# Altium schematic sheet beovox-poe.SchDoc(beovox-poe) (continued)

## Library data of the parts
C1 C3 = GRM32ER72A105KA01L: 1210 1 uF 100 V ±10% Tolerance X7R SMT Multilayer Ceramic Capacitor; Manufacturer: Murata; Case Code (Imperial): 1210; Case Code (Metric): 3225; Case/Package: 1210; Category: Ceramic Capacitors; Component Kind: Standard; ComponentLink1Description: https://datasheet.ciiva.com/11789/0900766b80ef921d-11789096.pdf?src-supplier=RSComponents; ComponentLink1URL: https://datasheet.ciiva.com/11789/0900766b80ef921d-11789096.pdf?src-supplier=RSComponents; ComponentLink2Description: https://datasheet.ciiva.com/3777/2750grm32er72a105ka0123-3777235.pdf?src-supplier=Arrow+Electronics; ComponentLink2URL: https://datasheet.ciiva.com/3777/2750grm32er72a105ka0123-3777235.pdf?src-supplier=Arrow+Electronics; ComponentLink3Description: https://datasheet.ciiva.com/29202/451519-da-01-de-vs-kondens-grm32-er72a-105ka01l-29202131.pdf?src-supplier=Conrad; ComponentLink3URL: https://datasheet.ciiva.com/29202/451519-da-01-de-vs-kondens-grm32-er72a-105ka01l-29202131.pdf?src-supplier=Conrad; ComponentLink4Description: https://datasheet.ciiva.com/7937/608985-7937781.pdf?src-supplier=Element14; ComponentLink4URL: https://datasheet.ciiva.com/7937/608985-7937781.pdf?src-supplier=Element14; ComponentLink5Description: https://datasheet.ciiva.com/22703/608985-22703400.pdf?src-supplier=Element14; ComponentLink5URL: https://datasheet.ciiva.com/22703/608985-22703400.pdf?src-supplier=Element14; ComponentLink6Description: https://datasheet.ciiva.com/141/partnumbering-e-01-141963.pdf?src-supplier=Digi-Key; ComponentLink6URL: https://datasheet.ciiva.com/141/partnumbering-e-01-141963.pdf?src-supplier=Digi-Key; ComponentLink7Description: https://datasheet.ciiva.com/141/partnumbering-e-01-141962.pdf?src-supplier=Digi-Key; ComponentLink7URL: https://datasheet.ciiva.com/141/partnumbering-e-01-141962.pdf?src-supplier=Digi-Key; ComponentLink8Description: https://datasheet.ciiva.com/141/partnumbering-e-01-141964.pdf?src-supplier=Digi-Key; ComponentLink8URL: https://datasheet.ciiva.com/141/partnumbering-e-01-141964.pdf?src-supplier=Digi-Key; ComponentLink9Description: https://datasheet.ciiva.com/5415/product-5415622.pdf?src-supplier=Mouser; ComponentLink9URL: https://datasheet.ciiva.com/5415/product-5415622.pdf?src-supplier=Mouser; ComponentLink10Description: https://datasheet.ciiva.com/16376/c02e-16376506.pdf?src-supplier=Future+Electronics; ComponentLink10URL: https://datasheet.ciiva.com/16376/c02e-16376506.pdf?src-supplier=Future+Electronics; Depth: 2.5mm; Dielectric: X7R; Height: 2.5mm; Lead Free: Lead Free; Length: 3.2mm; Manufacturer Part Number: GRM32ER72A105KA01L; Material: Ceramic; Max Operating Temperature: 125°C; Min Operating Temperature: -55°C; Mount: Surface Mount; Number of Pins: 2; Package Quantity: 1; Packaging: Tape and Reel; Pricing 1: 1000=0.33337, 2000=0.32553, 4000=0.31768, 6000=0.30984, 8000=0.30199 (USD); Reach SVHC Compliant: Yes; RoHS: Yes; RoHS Compliant: Yes; Series: GRM; Stock 1: 22500; Supplier 1: Avnet; Supplier Part Number 1: GRM32ER72A105KA01L; Termination: SMD/SMT; Thickness: 0.106inch; Tolerance: 10%; Voltage: 100V; Voltage Rating: 100V; Voltage Rating (DC): 100V; Width: 0.098inch; Library Name: josh-lib.SchLib
C2 C4 = CC0603KRX7R0BB104: 0603 0.1 uF 100 V ±10% Tolerance X7R Multilayer Ceramic Capacitor; Manufacturer: Yageo; Capacitance: 100nF; Voltage Rating: 100V; Case Code (Imperial): 0603; Case Code (Metric): 1608; Case/Package: 0603; Category: Ceramic Capacitors; Component Kind: Standard; ComponentLink1Description: https://datasheet.ciiva.com/6277/mlccorderingcode-6277082.pdf?src-supplier=Digi-Key; ComponentLink1URL: https://datasheet.ciiva.com/6277/mlccorderingcode-6277082.pdf?src-supplier=Digi-Key; ComponentLink2Description: https://datasheet.ciiva.com/6277/mlccorderingcode-6277081.pdf?src-supplier=Digi-Key; ComponentLink2URL: https://datasheet.ciiva.com/6277/mlccorderingcode-6277081.pdf?src-supplier=Digi-Key; ComponentLink3Description: https://datasheet.ciiva.com/6277/mlccorderingcode-6277030.pdf?src-supplier=Digi-Key; ComponentLink3URL: https://datasheet.ciiva.com/6277/mlccorderingcode-6277030.pdf?src-supplier=Digi-Key; ComponentLink4Description: LCSC; ComponentLink4URL: https://www.lcsc.com/product-detail/Multilayer-Ceramic-Capacitors-MLCC-SMD-SMT_YAGEO-CC0603KRX7R0BB104_C113803.html; Depth: 0.8mm; Dielectric: X7R; Lead Free: Lead Free; Length: 0.063inch; Manufacturer Part Number: CC0603KRX7R0BB104; Max Operating Temperature: 125°C; Min Operating Temperature: -55°C; Mount: Surface Mount; Package Quantity: 4000; Packaging: Tape and Reel; Pricing 1: 1=0.01580 (USD); Resistor Type: Ceramic; RoHS: Yes; RoHS Compliant: Yes; Series: CC; Stock 1: 2; Supplier: LCSC; Supplier 1: Arrow Electronics; Supplier Part Number: C113803; Supplier Part Number 1: CC0603KRX7R0BB104; Termination: SMD/SMT; Thickness: 0.035inch; Tolerance: 10%; Voltage Rating (DC): 100V; Weight: 7.1E-05oz; Width: 0.031inch; Library Name: josh-lib.SchLib
C5 C6 = ERR1VM102G20OT: 1000uF 35V ±20% Plugin,D10xL20mm Aluminum Electrolytic Capacitors - Leaded ROHS; Manufacturer: AISHI; Component Kind: Standard; ComponentLink1Description: LCSC; ComponentLink1URL: https://www.lcsc.com/product-detail/Aluminum-Electrolytic-Capacitors-Leaded_AISHI-Aihua-Group-ERR1VM102G20OT_C398835.html; Manufacturer Part Number: ERR1VM102G20OT; Supplier: LCSC; Supplier Part Number: C398835; Voltage: 35V; Library Name: josh-lib.SchLib
C7 C8 = 1206B472K202NT: 2kV 4700pF X7R ±10% 1206 Multilayer Ceramic Capacitors MLCC - SMD/SMT ROHS; Manufacturer: FH (Guangdong Fenghua Advanced Tech); Component Kind: Standard; ComponentLink1Description: LCSC; ComponentLink1URL: https://www.lcsc.com/product-detail/Multilayer-Ceramic-Capacitors-MLCC-SMD-SMT_FH-Guangdong-Fenghua-Advanced-Tech-1206B472K202NT_C41017.html; Manufacturer Part Number: 1206B472K202NT; Supplier: LCSC; Supplier Part Number: C41017; Library Name: josh-lib.SchLib
C9-C16 = 0603B103K101CT: Multilayer Ceramic Capacitor, 0.01 F, 10%, X7R, 100 V, 0603 [1608 Metric] Rohs Compliant: Yes; Manufacturer: Walsin Technologies; Voltage Rating: 100V; Capacitance: 10nF; Case Code (Imperial): 0603; Case Code (Metric): 1608; Case/Package: 0603; Category: Ceramic Capacitors; Component Kind: Standard; ComponentLink1Description: http://www.farnell.com/datasheets/2340522.pdf?src-supplier=Farnell; ComponentLink1URL: http://www.farnell.com/datasheets/2340522.pdf?src-supplier=Farnell; ComponentLink2Description: http://www.passivecomponent.com/wp-content/uploads/2018/10/MLCC.pdf?src-supplier=Digi-Key; ComponentLink2URL: http://www.passivecomponent.com/wp-content/uploads/2018/10/MLCC.pdf?src-supplier=Digi-Key; ComponentLink3Description: http://www.passivecomponent.com/indexsea/0603B103K101CT-IS.pdf?src-supplier=Digi-Key; ComponentLink3URL: http://www.passivecomponent.com/indexsea/0603B103K101CT-IS.pdf?src-supplier=Digi-Key; ComponentLink4Description: http://www.farnell.com/datasheets/2340522.pdf?src-supplier=Element14; ComponentLink4URL: http://www.farnell.com/datasheets/2340522.pdf?src-supplier=Element14; ComponentLink5Description: http://www.farnell.com/datasheets/2340522.pdf?src-supplier=Newark; ComponentLink5URL: http://www.farnell.com/datasheets/2340522.pdf?src-supplier=Newark; ComponentLink6Description: LCSC; ComponentLink6URL: https://www.lcsc.com/product-detail/Multilayer-Ceramic-Capacitors-MLCC-SMD-SMT_Walsin-Tech-Corp-0603B103K101CT_C302008.html; Depth: 0.8mm; Dielectric: X7R; Length: 1.6mm; Manufacturer Part Number: 0603B103K101CT; Max Operating Temperature: 125°C; Min Operating Temperature: -55°C; Packaging: Tape and Reel; Pricing 1: 4000=0.00810, 8000=0.00720, 12000=0.00620, 40000=0.00610 (USD); REACH SVHC: Unknown; RoHS: Yes; RoHS Compliant: Yes; Stock 1: 100000; Supplier: LCSC; Supplier 1: Arrow Electronics; Supplier Part Number: C302008; Supplier Part Number 1: 0603B103K101CT; Tolerance: 10%; Voltage Rating (DC): 100V; Library Name: josh-lib.SchLib
C17 C18 = CC1206KKX7RDBB102: Multilayer Ceramic Capacitor, 1000 pF, 2000 V, ± 10%, X7R, 1206 [3216 Metric]; Manufacturer: Yageo; Capacitance: 1nF; Dissipation Factor: 2.5%; Insulation Resistance: 10GR; Material: 4241ConductorMaterial; Case Code (Imperial): 1206; Case Code (Metric): 3216; Case/Package: 1206; Category: Ceramic Capacitors; Component Kind: Standard; ComponentLink1Description: https://datasheet.ciiva.com/23942/1449957-23942422.pdf?src-supplier=Newark; ComponentLink1URL: https://datasheet.ciiva.com/23942/1449957-23942422.pdf?src-supplier=Newark; ComponentLink2Description: https://datasheet.ciiva.com/186/upy-np0x7r-hv-1kv-to-3kv-4-186736.pdf?src-supplier=Digi-Key; ComponentLink2URL: https://datasheet.ciiva.com/186/upy-np0x7r-hv-1kv-to-3kv-4-186736.pdf?src-supplier=Digi-Key; ComponentLink3Description: https://datasheet.ciiva.com/186/upy-np0x7r-hv-1kv-to-3kv-4-186735.pdf?src-supplier=Digi-Key; ComponentLink3URL: https://datasheet.ciiva.com/186/upy-np0x7r-hv-1kv-to-3kv-4-186735.pdf?src-supplier=Digi-Key; ComponentLink4Description: https://datasheet.ciiva.com/186/upy-np0x7r-hv-1kv-to-3kv-4-186737.pdf?src-supplier=Digi-Key; ComponentLink4URL: https://datasheet.ciiva.com/186/upy-np0x7r-hv-1kv-to-3kv-4-186737.pdf?src-supplier=Digi-Key; ComponentLink5Description: https://datasheet.ciiva.com/13386/upy-gp-np0-16v-to-50v-8-13386312.pdf?src-supplier=Mouser; ComponentLink5URL: https://datasheet.ciiva.com/13386/upy-gp-np0-16v-to-50v-8-13386312.pdf?src-supplier=Mouser; ComponentLink6Description: LCSC; ComponentLink6URL: https://www.lcsc.com/product-detail/Multilayer-Ceramic-Capacitors-MLCC-SMD-SMT_YAGEO-CC1206KKX7RDBB102_C23631.html; Construction: Flat; Depth: 1.6mm; Dielectric: X7R; Height: 1.25mm; Lead Free: Lead Free; Length: 3.2mm; Manufacturer Part Number: CC1206KKX7RDBB102; Max Operating Temperature: 125°C; Min Operating Temperature: -55°C; Mount: Surface Mount; Number of Pins: 2; Package Quantity: 1; Packaging: Tape and Reel; Pricing 1: 3000=0.0177, 9000=0.0171, 30000=0.0164, 60000=0.016, 120000=0.0154 (USD); Radiation Hardening: No; Reach SVHC Compliant: Unknown; RoHS: Yes; RoHS Compliant: Yes; Series: CC; Stock 1: 1107000; Supplier: LCSC; Supplier 1: Future Electronics; Supplier Part Number: C23631; Supplier Part Number 1: 2008092; Termination: SMD/SMT; Thickness: 0.057inch; Tolerance: 10%; Value: 1000pF 2kV; Voltage: 2kV; Voltage Rating: 2kV; Voltage Rating (DC): 2kV; Width: 0.063inch; Library Name: josh-lib.SchLib
C19 = ERS1KM470F12OT: 47uF 100V ±20% Plugin,D8xL12mm Aluminum Electrolytic Capacitors - Leaded ROHS; Manufacturer: AISHI(Aihua Group); Component Kind: Standard; ComponentLink1Description: LCSC; ComponentLink1URL: https://www.lcsc.com/product-detail/Aluminum-Electrolytic-Capacitors-Leaded_AISHI-Aihua-Group-ERS1KM470F12OT_C106688.html; Manufacturer Part Number: ERS1KM470F12OT; Supplier: LCSC; Supplier Part Number: C106688; Library Name: josh-lib.SchLib
CONN1 = R-RJ45R08P-C000: RJ45 RJReceptacle 1 有屏蔽 Right-Angle WithLED 0℃~+70℃ Plugin Ethernet Connectors/Modular Connectors (RJ45 RJ11) ROHS; Manufacturer: Ckmtw; Component Kind: Standard; ComponentLink1Description: LCSC; ComponentLink1URL: https://www.lcsc.com/product-detail/Ethernet-Connectors-Modular-Connectors-RJ45-RJ11_Ckmtw-Shenzhen-Cankemeng-R-RJ45R08P-C000_C386757.html; Manufacturer Part Number: R-RJ45R08P-C000; Supplier: LCSC; Supplier Part Number: C386757; Library Name: josh-lib.SchLib
CONN2 = R-RJ45S08P-C000: RJ45 RJReceptacle 1 有屏蔽 Straight WithLED 0℃~+70℃ Plugin Ethernet Connectors/Modular Connectors (RJ45 RJ11) ROHS; Manufacturer: Ckmtw(Shenzhen Cankemeng); Component Kind: Standard; ComponentLink1Description: LCSC; ComponentLink1URL: https://www.lcsc.com/product-detail/Ethernet-Connectors-Modular-Connectors-RJ45-RJ11_Ckmtw-Shenzhen-Cankemeng-R-RJ45S08P-C000_C386764.html; Manufacturer Part Number: R-RJ45S08P-C000; Supplier: LCSC; Supplier Part Number: C386764; Library Name: josh-lib.SchLib
CONN3 = DC-005H-D020: Right-Angle DC Power Receptacle -30℃~+85℃ 2mm 5.8mm 7A 35V Plugin AC/DC Power Connectors ROHS; Manufacturer: G-Switch; Component Kind: Standard; ComponentLink1Description: LCSC; ComponentLink1URL: https://www.lcsc.com/product-detail/AC-DC-Power-Connectors_G-Switch-DC-005H-D020_C5155559.html; Manufacturer Part Number: DC-005H-D020; Supplier: LCSC; Supplier Part Number: C5155559; Library Name: josh-lib.SchLib
D1-D4 D7-D10 = SS36: 60V 700mV@3A 3A SMA(DO-214AC) Schottky Barrier Diodes (SBD) ROHS; Manufacturer: MDD; Value: 60V 3A; Component Kind: Standard; ComponentLink1Description: LCSC; ComponentLink1URL: https://www.lcsc.com/product-detail/Schottky-Barrier-Diodes-SBD_MDD-Microdiode-Electronics-SS36_C16015.html; Manufacturer Part Number: SS36; Supplier: LCSC; Supplier Part Number: C16015; Library Name: josh-lib.SchLib
D5 D11 = B260-13-F: VR=60V IF=2A 200pF; Manufacturer: Diodes; Element Configuration: Single; Forward Voltage: 700mV; Max Forward Surge Current (Ifsm): 50A; Max Reverse Current: 500uA; Polarity: Standard; Capacitance: 200pF; Case/Package: SMB; Category: Rectifier Diodes; Component Kind: Standard; ComponentLink1Description: https://datasheet.ciiva.com/26949/getdatasheetpartid-116011-26949343.pdf?src-supplier=Verical; ComponentLink1URL: https://datasheet.ciiva.com/26949/getdatasheetpartid-116011-26949343.pdf?src-supplier=Verical; ComponentLink2Description: https://datasheet.ciiva.com/16199/ds13004-16199944.pdf?src-supplier=Future+Electronics; ComponentLink2URL: https://datasheet.ciiva.com/16199/ds13004-16199944.pdf?src-supplier=Future+Electronics; ComponentLink3Description: https://datasheet.ciiva.com/4715/ds13004-70120-4715821.pdf?src-supplier=Mouser; ComponentLink3URL: https://datasheet.ciiva.com/4715/ds13004-70120-4715821.pdf?src-supplier=Mouser; ComponentLink4Description: LCSC; ComponentLink4URL: https://www.lcsc.com/product-detail/Schottky-Barrier-Diodes-SBD_Diodes-Incorporated-B260-13-F_C72265.html; Contact Plating: Tin,Matte; Current: 2A; Current Rating: 2A; Forward Current: 2A; Height: 2.62mm; Lead Free: Lead Free; Length: 4.57mm; Manufacturer Part Number: B260-13-F; Max Operating Temperature: 150°C; Max Reverse Voltage (DC): 60V; Min Operating Temperature: -65°C; Mount: Surface Mount; Number of Pins: 2; Output Current: 2A; Package Quantity: 3000; Packaging: Cut Tape; Peak Non-Repetitive Surge Current: 50A; Pricing 1: 1=0.45000, 5=0.45000, 10=0.36200, 25=0.32300, 50=0.28500, 100=0.24600, 250=0.20600, 500=0.16700, 1000=0.13400 (USD); Radiation Hardening: No; Reach SVHC Compliant: Yes; RoHS: Yes; RoHS Compliant: Yes; Series: -; Stock 1: 2763; Supplier: LCSC; Supplier 1: Newark; Supplier Part Number: C72265; Supplier Part Number 1: 23T4612; Termination: SMD/SMT; Voltage: 60V; Voltage Rating (DC): 60V; Weight: 0.00328oz; Width: 3.94mm; Library Name: josh-lib.SchLib
  D5: Average Rectified Current: 2A
D6 = SMAJ58A: 4.3A 400W 93.6V 64.4V 58V SMA(DO-214AC) TVS ROHS; Manufacturer: GOODWORK; Value: 58V 400W; Component Kind: Standard; ComponentLink1Description: LCSC; ComponentLink1URL: https://www.lcsc.com/product-detail/TVS_GOODWORK-SMAJ58A_C2980408.html; Manufacturer Part Number: SMAJ58A; Supplier: LCSC; Supplier Part Number: C2980408; Library Name: josh-lib.SchLib
D12 = FM-3510RGBA-SG: Side stickers -30℃~+85℃ Common anode RGB 110° SMD,1.3x3.5mm Light Emitting Diodes (LED) ROHS; Manufacturer: Foshan NationStar Optoelectronics; Component Kind: Standard; ComponentLink1Description: LCSC; ComponentLink1URL: https://www.lcsc.com/product-detail/Light-Emitting-Diodes-LED_Foshan-NationStar-Optoelectronics-FM-3510RGBA-SG_C727903.html; Manufacturer Part Number: FM-3510RGBA-SG; Supplier: LCSC; Supplier Part Number: C727903; Library Name: josh-lib.SchLib
FB1-FB6 = MPZ2012S601AT000: Ferrite Beads Multi-Layer Power 600Ohm 25% 100MHz 2A 100mOhm DCR 0805 T/R; Manufacturer: TDK; DC Resistance (DCR): 100mR; Impedance: 600R; Number of Lines: 1; Case Code (Imperial): 0805; Case Code (Metric): 2012; Case/Package: 0805; Category: Ferrite Beads and Chips; Component Kind: Standard; ComponentLink1Description: https://datasheet.ciiva.com/46634/beads-commercial-power-mpz-en-46634335.pdf?src-supplier=Digi-Key; ComponentLink1URL: https://datasheet.ciiva.com/46634/beads-commercial-power-mpz-en-46634335.pdf?src-supplier=Digi-Key; ComponentLink2Description: https://datasheet.ciiva.com/46634/beads-commercial-power-mpz-en-46634377.pdf?src-supplier=Digi-Key; ComponentLink2URL: https://datasheet.ciiva.com/46634/beads-commercial-power-mpz-en-46634377.pdf?src-supplier=Digi-Key; ComponentLink3Description: https://datasheet.ciiva.com/46634/beads-commercial-power-mpz-en-46634606.pdf?src-supplier=Digi-Key; ComponentLink3URL: https://datasheet.ciiva.com/46634/beads-commercial-power-mpz-en-46634606.pdf?src-supplier=Digi-Key; ComponentLink4Description: LCSC; ComponentLink4URL: https://www.lcsc.com/product-detail/Ferrite-Beads_TDK-MPZ2012S601AT000_C21519.html; Core Material: Ferrite; Current Rating: 2A; Height: 0.041inch; Lead Free: Lead Free; Length: 0.079inch; Manufacturer Part Number: MPZ2012S601AT000; Max Current Rating: 2A; Max DC Current: 2A; Max Operating Temperature: 125°C; Min Operating Temperature: -55°C; Mount: Surface Mount; Package Quantity: 1; Packaging: Tape and Reel; Pricing 1: 1=0.12, 10=0.094, 25=0.0848, 50=0.0622, 100=0.0537, 250=0.0424, 500=0.03582, 1000=0.03016 (USD); Reach SVHC Compliant: No; RMS Current (Irms): 2A; RoHS: Yes; RoHS Compliant: Yes; Series: MPZ; Series Resistance: 100mR; Stock 1: 54486; Supplier: LCSC; Supplier 1: Digi-Key; Supplier Part Number: C21519; Supplier Part Number 1: 445-MPZ2012S601AT000CT-ND; Test Frequency: 100MHz; Tolerance: 25%; Width: 0.049inch; Library Name: josh-lib.SchLib
J1 = DB125-3.81-2P-GN-S: 1x2P 10A -40℃~+105℃ 300V Green 16~26 Straight pin 3.81mm 0.5~1 1 2 Plugin,P=3.81mm Screw terminal ROHS; Manufacturer: DIBO; Component Kind: Standard; ComponentLink1Description: LCSC; ComponentLink1URL: https://www.lcsc.com/product-detail/Screw-terminal_DIBO-DB125-3-81-2P-GN-S_C395878.html; Manufacturer Part Number: DB125-3.81-2P-GN-S; Supplier: LCSC; Supplier Part Number: C395878; Library Name: josh-lib.SchLib
J2 = PZ254V-11-01P: Straight Square Pins 2.5mm 6mm -40℃~+105℃ 3mm 1 2.54mm Plugin Black Brass 1x1P Plugin,P=2.54mm Pin Headers ROHS; Manufacturer: XFCN; Component Kind: Standard; ComponentLink1Description: LCSC; ComponentLink1URL: https://www.lcsc.com/product-detail/Pin-Headers_XFCN-PZ254V-11-01P_C492400.html; Manufacturer Part Number: PZ254V-11-01P; Supplier: LCSC; Supplier Part Number: C492400; Library Name: josh-lib.SchLib
J3 J4 = Solder_Jumper_3: Component Kind: Standard; Library Name: josh-lib.SchLib
MP1-MP4 = SMTSOM380BTR: M3 Round Nut 8mm height; Manufacturer: YIYUAN; Component Kind: Standard; ComponentLink1Description: LCSC; ComponentLink1URL: https://www.lcsc.com/product-detail/Other-nuts_YIYUAN-SMTSOM380BTR_C5301772.html; Manufacturer Part Number: SMTSOM380BTR; Supplier: LCSC; Supplier Part Number: C5301772; Library Name: josh-lib.SchLib
Q1 Q2 = BSZ097N10NS5ATMA1: BSZ097xx Series 100 V 40 A 9.7 mOhm OptiMOS5 Power-MOSFET - TSDSON-8 FL; Manufacturer: Infineon; Drain to Source Resistance: 13mR; Fall Time: 5ns; Gate to Source Voltage (Vgs): 20V; Input Capacitance: 2.08nF; Max Power Dissipation: 69W; Number of Channels: 1; On-State Resistance: 9.7mR; Polarity: N-CHANNEL; Turn-Off Delay Time: 21ns; Turn-On Delay Time: 11ns; Category: MOSFETs; Component Kind: Standard; ComponentLink1Description: http://www.mouser.com/ds/2/196/Infineon-BSZ097N10NS5-DS-v02_01-en-531392.pdf?src-supplier=Mouser; ComponentLink1URL: http://www.mouser.com/ds/2/196/Infineon-BSZ097N10NS5-DS-v02_01-en-531392.pdf?src-supplier=Mouser; ComponentLink2Description: http://www.farnell.com/datasheets/2255497.pdf?src-supplier=Farnell; ComponentLink2URL: http://www.farnell.com/datasheets/2255497.pdf?src-supplier=Farnell; ComponentLink3Description: https://www.infineon.com/dgdl/Infineon-BSZ097N10NS5-DS-v02_01-en.pdf?fileId=5546d461454603990145d5a1b051648d&src-supplier=Digikey; ComponentLink3URL: https://www.infineon.com/dgdl/Infineon-BSZ097N10NS5-DS-v02_01-en.pdf?fileId=5546d461454603990145d5a1b051648d&src-supplier=Digikey; ComponentLink4Description: http://www.infineon.com/dgdl/Infineon-BSZ097N10NS5-DS-v02_01-en.pdf?fileId=5546d461454603990145d5a1b051648d&src-supplier=Digikey; ComponentLink4URL: http://www.infineon.com/dgdl/Infineon-BSZ097N10NS5-DS-v02_01-en.pdf?fileId=5546d461454603990145d5a1b051648d&src-supplier=Digikey; Halogen Free: Halogen Free; Lead Free: Contains Lead; Manufacturer Part Number: BSZ097N10NS5ATMA1; Max Dual Supply Voltage: 100V; Max Operating Temperature: 150°C; Min Operating Temperature: -55°C; Mount: Surface Mount; Number of Pins: 8; Package Quantity: 5000; Packaging: Tape and Reel; Power Dissipation: 69W; Pricing 1: 1=1.81, 10=1.5, 50=1.5, 100=1.2, 1000=0.892, 10000=0.757 (USD); Rds On Max: 9.7mR; Rise Time: 5ns; RoHS: Yes; RoHS Compliant: Yes; Series: OptiMOS™; Stock 1: 24743; Supplier 1: Mouser; Supplier Part Number 1: 726-BSZ097N10NS5; Library Name: josh-lib.SchLib
R1 R3 = RC0603FR-07100RL: Res Thick Film 0603 100 Ohm 1% 1/10W ±100ppm/°C Molded SMD Paper T/R; Manufacturer: Yageo; Max Power Dissipation: 100mW; Resistance: 100R; Voltage Rating: 50V; Case Code (Imperial): 0603; Case Code (Metric): 1608; Case/Package: 0603; Category: Chip SMD Resistors; Component Kind: Standard; ComponentLink1Description: https://datasheet.ciiva.com/7660/38590-7660788.pdf?src-supplier=Element14; ComponentLink1URL: https://datasheet.ciiva.com/7660/38590-7660788.pdf?src-supplier=Element14; ComponentLink2Description: https://datasheet.ciiva.com/11808/0900766b80f96399-11808280.pdf?src-supplier=Rs; ComponentLink2URL: https://datasheet.ciiva.com/11808/0900766b80f96399-11808280.pdf?src-supplier=Rs; ComponentLink3Description: https://datasheet.ciiva.com/22747/443971-22747518.pdf?src-supplier=Element14; ComponentLink3URL: https://datasheet.ciiva.com/22747/443971-22747518.pdf?src-supplier=Element14; ComponentLink4Description: https://datasheet.ciiva.com/46440/1868787-46440890.pdf?src-supplier=Element14; ComponentLink4URL: https://datasheet.ciiva.com/46440/1868787-46440890.pdf?src-supplier=Element14; ComponentLink5Description: https://datasheet.ciiva.com/7746/1738695-7746238.pdf?src-supplier=Element14; ComponentLink5URL: https://datasheet.ciiva.com/7746/1738695-7746238.pdf?src-supplier=Element14; ComponentLink6Description: https://datasheet.ciiva.com/7746/1738695-7746237.pdf?src-supplier=Element14; ComponentLink6URL: https://datasheet.ciiva.com/7746/1738695-7746237.pdf?src-supplier=Element14; ComponentLink7Description: LCSC; ComponentLink7URL: https://www.lcsc.com/product-detail/Chip-Resistor-Surface-Mount_YAGEO-RC0603FR-07100RL_C105588.html; Construction: Rectangular; Depth: 0.8mm; Features: Moisture Resistant; Height: 0.45mm; Lead Free: Lead Free; Length: 1.6mm; Manufacturer Part Number: RC0603FR-07100RL; Max Operating Temperature: 155°C; Military Standard: Not; Min Operating Temperature: -55°C; Number of Pins: 2; Number of Terminations: 2; Packaging: Tape and Reel; Power Rating: 100mW; Pricing 1: 5000=0.00150, 50000=0.00140, 200000=0.00140, 625000=0.00130, 2000000=0.00120 (USD); Resistance Tolerance: 1%; Resistor Type: Thick Film; RoHS: Yes; RoHS Compliant: Yes; Series: RC; Size Code: 0603; Stock 1: 13935000; Supplier: LCSC; Supplier 1: Future Electronics; Supplier Part Number: C105588; Supplier Part Number 1: 7334493; Technology: THICK FILM; Temperature Coefficient: 100ppm/°C; Termination: SMD/SMT; Tolerance: 1%; Voltage Rating (DC): 50V; Width: 0.8mm; Library Name: josh-lib.SchLib
R2 = RC0805JR-070RL: Surface Mount Thick Film Resistor, RC Series, 125 mW, - 5%, 150 V, 0805 [2012 Metric]; Manufacturer: Yageo; Max Power Dissipation: 125mW; Resistance: 0mR; Temperature Coefficient: 200ppm/°C; Voltage Rating: 150V; Case Code (Imperial): 0805; Case Code (Metric): 2012; Case/Package: 0805; Category: Chip SMD Resistors; Component Kind: Standard; ComponentLink1Description: https://datasheet.ciiva.com/11819/0900766b8106beab-11819430.pdf?src-supplier=RSComponents; ComponentLink1URL: https://datasheet.ciiva.com/11819/0900766b8106beab-11819430.pdf?src-supplier=RSComponents; ComponentLink2Description: https://datasheet.ciiva.com/7941/1738694-7941861.pdf?src-supplier=Element14; ComponentLink2URL: https://datasheet.ciiva.com/7941/1738694-7941861.pdf?src-supplier=Element14; ComponentLink3Description: https://datasheet.ciiva.com/7941/1738694-7941862.pdf?src-supplier=Element14; ComponentLink3URL: https://datasheet.ciiva.com/7941/1738694-7941862.pdf?src-supplier=Element14; ComponentLink4Description: https://datasheet.ciiva.com/7941/1738694-7941863.pdf?src-supplier=Element14; ComponentLink4URL: https://datasheet.ciiva.com/7941/1738694-7941863.pdf?src-supplier=Element14; ComponentLink5Description: https://datasheet.ciiva.com/15190/getdatasheetpartid-330685-15190028.pdf?src-supplier=Verical; ComponentLink5URL: https://datasheet.ciiva.com/15190/getdatasheetpartid-330685-15190028.pdf?src-supplier=Verical; Construction: Rectangular; Contact Plating: Tin,Matte; Depth: 1.25mm; Features: Moisture Resistant; Height: 0.5mm; Lead Free: Lead Free; Length: 2mm; Manufacturer Part Number: RC0805JR-070RL; Max Operating Temperature: 155°C; Military Standard: Not; Min Operating Temperature: -55°C; Number of Pins: 2; Number of Terminations: 2; Packaging: Tape and Reel; Power Rating: 125mW; Pricing 1: 5000=0.00430, 10000=0.00390, 25000=0.00350, 50000=0.00270 (USD); Radiation Hardening: No; REACH SVHC: Unknown; Resistance Tolerance: 5%; Resistor Type: Thick Film; RoHS: Yes; RoHS Compliant: Yes; Series: RC; Size Code: 0805; Stock 1: 5861000; Supplier 1: Arrow Electronics; Supplier Part Number 1: RC0805JR-070RL; Technology: THICK FILM; Termination: SMD/SMT; Tolerance: 5%; Voltage Rating (DC): 150V; Width: 1.25mm; Library Name: josh-lib.SchLib
R4-R6 R8 R18 R19 = ERJ3EKF1002V: RES SMD 10K OHM 1% 1/10W 0603; Manufacturer: Panasonic; Max Power Dissipation: 100mW; Resistance: 10kR; Temperature Coefficient: 100ppm/°C; Voltage Rating: 75V; Case Code (Imperial): 0603; Case Code (Metric): 1608; Case/Package: 0603; Component Kind: Standard; ComponentLink1Description: https://datasheet.ciiva.com/16511/aoa0000ce2-16511861.pdf?src-supplier=Avnet+Asia; ComponentLink1URL: https://datasheet.ciiva.com/16511/aoa0000ce2-16511861.pdf?src-supplier=Avnet+Asia; ComponentLink2Description: https://datasheet.ciiva.com/46372/1678782-46372725.pdf?src-supplier=Element14; ComponentLink2URL: https://datasheet.ciiva.com/46372/1678782-46372725.pdf?src-supplier=Element14; ComponentLink3Description: https://datasheet.ciiva.com/7830/aoa0000ce2-7830729.pdf?src-supplier=Element14; ComponentLink3URL: https://datasheet.ciiva.com/7830/aoa0000ce2-7830729.pdf?src-supplier=Element14; ComponentLink4Description: https://datasheet.ciiva.com/48530/aoa0000ce2-48530988.pdf?src-supplier=Avnet; ComponentLink4URL: https://datasheet.ciiva.com/48530/aoa0000ce2-48530988.pdf?src-supplier=Avnet; ComponentLink5Description: https://datasheet.ciiva.com/28514/426256-28514198.pdf?src-supplier=Element14; ComponentLink5URL: https://datasheet.ciiva.com/28514/426256-28514198.pdf?src-supplier=Element14; ComponentLink6Description: https://datasheet.ciiva.com/9194/aoa0000ce2-9194199.pdf?src-supplier=Avnet; ComponentLink6URL: https://datasheet.ciiva.com/9194/aoa0000ce2-9194199.pdf?src-supplier=Avnet; ComponentLink7Description: https://datasheet.ciiva.com/17212/aoa0000ce2-17212105.pdf?src-supplier=Avnet+Europe; ComponentLink7URL: https://datasheet.ciiva.com/17212/aoa0000ce2-17212105.pdf?src-supplier=Avnet+Europe; ComponentLink8Description: https://datasheet.ciiva.com/30208/aoa0000ce2-30208985.pdf?src-supplier=Onlinecomponents.com; ComponentLink8URL: https://datasheet.ciiva.com/30208/aoa0000ce2-30208985.pdf?src-supplier=Onlinecomponents.com; ComponentLink9Description: https://datasheet.ciiva.com/24070/aoa0000ce2-24070318.pdf?src-supplier=Mouser; ComponentLink9URL: https://datasheet.ciiva.com/24070/aoa0000ce2-24070318.pdf?src-supplier=Mouser; ComponentLink10Description: https://datasheet.ciiva.com/16759/70260748-16759807.pdf?src-supplier=Allied+Electronics; ComponentLink10URL: https://datasheet.ciiva.com/16759/70260748-16759807.pdf?src-supplier=Allied+Electronics; Composition: Thick Film; Contact Plating: Tin; Depth: 0.8mm; Features: Automotive AEC-Q200; Height: 0.45mm; Lead Free: Lead Free; Length: 1.6mm; Manufacturer Part Number: ERJ3EKF1002V; Max Operating Temperature: 155°C; Military Standard: Not; Min Operating Temperature: -55°C; Mount: Surface Mount; Number of Pins: 2; Number of Terminations: 2; Package Quantity: 1; Packaging: Tape and Reel; Power Rating: 100mW; Pricing 1: 5000 = 0.00810, 10000 = 0.00710, 25000 = 0.00640, 50000 = 0.00570, 125000 = 0.00550 (USD); Radiation Hardening: No; REACH SVHC: No SVHC; RoHS: Yes; Stock 1: 780000; Supplier 1: Arrow; Supplier Part Number 1: ERJ-3EKF1002V; Termination: SMD/SMT; Tolerance: 1%; Voltage Rating (DC): 75V; Width: 0.031inch; Library Name: josh-lib.SchLib
R7 = RC0603FR-071KL: Res Thick Film 0603 1K Ohm 1% 1/10W ±100ppm/°C Molded SMD SMD Paper T/R; Manufacturer: Yageo; Max Power Dissipation: 100mW; Resistance: 1kR; Voltage Rating: 50V; Case Code (Imperial): 0603; Case Code (Metric): 1608; Case/Package: 0603; Category: Chip SMD Resistors; Component Kind: Standard; ComponentLink1Description: https://datasheet.ciiva.com/11808/0900766b80f96399-11808081.pdf?src-supplier=Rs; ComponentLink1URL: https://datasheet.ciiva.com/11808/0900766b80f96399-11808081.pdf?src-supplier=Rs; ComponentLink2Description: https://datasheet.ciiva.com/7746/1686128-7746280.pdf?src-supplier=Element14; ComponentLink2URL: https://datasheet.ciiva.com/7746/1686128-7746280.pdf?src-supplier=Element14; ComponentLink3Description: https://datasheet.ciiva.com/7660/1868787-7660790.pdf?src-supplier=Element14; ComponentLink3URL: https://datasheet.ciiva.com/7660/1868787-7660790.pdf?src-supplier=Element14; ComponentLink4Description: https://datasheet.ciiva.com/7983/443971-7983613.pdf?src-supplier=Element14; ComponentLink4URL: https://datasheet.ciiva.com/7983/443971-7983613.pdf?src-supplier=Element14; ComponentLink5Description: https://datasheet.ciiva.com/7746/1738695-7746281.pdf?src-supplier=Element14; ComponentLink5URL: https://datasheet.ciiva.com/7746/1738695-7746281.pdf?src-supplier=Element14; ComponentLink6Description: https://datasheet.ciiva.com/7746/1738695-7746279.pdf?src-supplier=Element14; ComponentLink6URL: https://datasheet.ciiva.com/7746/1738695-7746279.pdf?src-supplier=Element14; ComponentLink7Description: https://datasheet.ciiva.com/8896/pyu-rc0603-51-rohs-l-4-8896106.pdf?src-supplier=Avnet; ComponentLink7URL: https://datasheet.ciiva.com/8896/pyu-rc0603-51-rohs-l-4-8896106.pdf?src-supplier=Avnet; ComponentLink8Description: https://datasheet.ciiva.com/15189/getdatasheetpartid-404327-15189644.pdf?src-supplier=Verical; ComponentLink8URL: https://datasheet.ciiva.com/15189/getdatasheetpartid-404327-15189644.pdf?src-supplier=Verical; ComponentLink9Description: LCSC; ComponentLink9URL: https://www.lcsc.com/product-detail/Chip-Resistor-Surface-Mount_YAGEO-RC0603FR-071KL_C22548.html; Construction: Rectangular; Contact Plating: Tin,Matte; Depth: 0.8mm; Features: Moisture Resistant; Height: 0.45mm; Lead Free: Lead Free; Length: 1.6mm; Manufacturer Part Number: RC0603FR-071KL; Max Operating Temperature: 155°C; Military Standard: Not; Min Operating Temperature: -55°C; Number of Terminations: 2; Packaging: Tape and Reel; Power Rating: 100mW; Pricing 1: 5000=0.0015, 50000=0.0014, 200000=0.0014, 625000=0.0013, 2000000=0.0012 (USD); Reach SVHC Compliant: No; Resistance Tolerance: 1%; Resistor Type: Thick Film; RoHS: Yes; RoHS Compliant: Yes; Series: RC; Size Code: 0603; Stock 1: 16270000; Supplier: LCSC; Supplier 1: Future Electronics; Supplier Part Number: C22548; Supplier Part Number 1: 4016291; Technology: THICK FILM; Temperature Coefficient: 100ppm/°C; Termination: SMD/SMT; Tolerance: 1%; Library Name: josh-lib.SchLib
R9 = RC0603FR-0791KL: SMD Chip Resistor, 91 kOhm, ± 1%, 100 mW, 0603 [1608 Metric], Thick Film, General Purpose; Manufacturer: Yageo; Max Power Dissipation: 100mW; Resistance: 91kR; Temperature Coefficient: 100ppm/°C; Voltage Rating: 75V; Voltage Rating (DC): 50V; Case Code (Imperial): 0603; Case Code (Metric): 1608; Case/Package: 0603; Category: Chip SMD Resistors; Component Kind: Standard; ComponentLink1Description: https://datasheet.ciiva.com/11819/0900766b80c66297-11819716.pdf?src-supplier=Rs; ComponentLink1URL: https://datasheet.ciiva.com/11819/0900766b80c66297-11819716.pdf?src-supplier=Rs; ComponentLink2Description: https://datasheet.ciiva.com/15189/getdatasheetpartid-326529-15189837.pdf?src-supplier=Verical; ComponentLink2URL: https://datasheet.ciiva.com/15189/getdatasheetpartid-326529-15189837.pdf?src-supplier=Verical; ComponentLink3Description: LCSC; ComponentLink3URL: https://www.lcsc.com/product-detail/Chip-Resistor-Surface-Mount_YAGEO-RC0603FR-0791KL_C137671.html; Construction: Rectangular; Depth: 0.8mm; Features: Moisture Resistant; Height: 0.45mm; Lead Free: Lead Free; Length: 1.6mm; Manufacturer Part Number: RC0603FR-0791KL; Max Operating Temperature: 155°C; Military Standard: Not; Min Operating Temperature: -55°C; Mount: Surface Mount; Number of Terminations: 2; Packaging: Tape and Reel; Power Rating: 100mW; Pricing 1: 5000=0.0013, 50000=0.0013, 150000=0.0012, 375000=0.0012, 1000000=0.0011 (USD); RoHS: Yes; RoHS Compliant: Yes; Series: RC; Size Code: 0603; Stock 1: 250000; Supplier: LCSC; Supplier 1: Future Electronics; Supplier Part Number: C137671; Supplier Part Number 1: 1365832; Technology: THICK FILM; Termination: SMD/SMT; Tolerance: 1%; Value: 91k; Weight: 7.1E-05oz; Width: 0.8mm; Library Name: josh-lib.SchLib
R10-R17 = RC0603FR-0775RL: SMD Chip Resistor, 75 Ohm, ± 1%, 100 mW, 0603 [1608 Metric], Thick Film, General Purpose; Manufacturer: Yageo; Max Power Dissipation: 100mW; Resistance: 75R; Temperature Coefficient: 100ppm/°C; Value: 75R; Voltage Rating: 50V; Case Code (Imperial): 0603; Case Code (Metric): 1608; Case/Package: 0603; Category: Chip SMD Resistors; Component Kind: Standard; ComponentLink1Description: https://datasheet.ciiva.com/11819/0900766b80f96399-11819407.pdf?src-supplier=Rs; ComponentLink1URL: https://datasheet.ciiva.com/11819/0900766b80f96399-11819407.pdf?src-supplier=Rs; ComponentLink2Description: https://datasheet.ciiva.com/46395/443971-46395018.pdf?src-supplier=Element14; ComponentLink2URL: https://datasheet.ciiva.com/46395/443971-46395018.pdf?src-supplier=Element14; ComponentLink3Description: https://datasheet.ciiva.com/2453/pyu-r-int-thick-7-2453962.pdf?src-supplier=Digi-Key; ComponentLink3URL: https://datasheet.ciiva.com/2453/pyu-r-int-thick-7-2453962.pdf?src-supplier=Digi-Key; ComponentLink4Description: https://datasheet.ciiva.com/2453/pyu-r-int-thick-7-2453963.pdf?src-supplier=Digi-Key; ComponentLink4URL: https://datasheet.ciiva.com/2453/pyu-r-int-thick-7-2453963.pdf?src-supplier=Digi-Key; ComponentLink5Description: https://datasheet.ciiva.com/2453/pyu-r-int-thick-7-2453961.pdf?src-supplier=Digi-Key; ComponentLink5URL: https://datasheet.ciiva.com/2453/pyu-r-int-thick-7-2453961.pdf?src-supplier=Digi-Key; ComponentLink6Description: LCSC; ComponentLink6URL: https://www.lcsc.com/product-detail/Chip-Resistor-Surface-Mount_YAGEO-RC0603FR-0775RL_C112309.html; Construction: Rectangular; Depth: 0.8mm; Features: Moisture Resistant; Height: 0.022inch; Lead Free: 5697LeadFree; Length: 0.063inch; Manufacturer Part Number: RC0603FR-0775RL; Max Operating Temperature: 155°C; Military Standard: Not; Min Operating Temperature: -55°C; Number of Terminations: 2; Package Quantity: 1; Packaging: Tape and Reel; Power Rating: 100mW; Pricing 1: 5000=0.0014, 50000=0.0013, 200000=0.0012, 625000=0.0012, 2000000=0.0011 (USD); Reach SVHC Compliant: No; Resistance Tolerance: 1%; Resistor Type: Thick Film; RoHS: Yes; RoHS Compliant: Yes; Series: RC; Size Code: 0603; Stock 1: 775000; Supplier: LCSC; Supplier 1: Future Electronics; Supplier Part Number: C112309; Supplier Part Number 1: 4693131; Technology: THICK FILM; Termination: SMD/SMT; Tolerance: 1%; Voltage Rating (DC): 50V; Width: 0.031inch; Library Name: josh-lib.SchLib
R20 = RC0603FR-078K2L: Res Thick Film 0603 8.2K Ohm 1% 0.1W(1/10W) ±100ppm/C Pad SMD T/R; Manufacturer: Yageo; Max Power Dissipation: 100mW; Resistance: 8.2kR; Temperature Coefficient: 100ppm/°C; Value: 10kR; Voltage Rating: 50V; Case Code (Imperial): 0603; Case Code (Metric): 1608; Case/Package: 0603; Category: Chip SMD Resistors; Component Kind: Standard; ComponentLink1Description: https://datasheet.ciiva.com/11808/0900766b80f96399-11808128.pdf?src-supplier=Rs; ComponentLink1URL: https://datasheet.ciiva.com/11808/0900766b80f96399-11808128.pdf?src-supplier=Rs; ComponentLink2Description: https://datasheet.ciiva.com/7746/443971-7746409.pdf?src-supplier=Element14; ComponentLink2URL: https://datasheet.ciiva.com/7746/443971-7746409.pdf?src-supplier=Element14; ComponentLink3Description: https://datasheet.ciiva.com/7746/443971-7746408.pdf?src-supplier=Element14; ComponentLink3URL: https://datasheet.ciiva.com/7746/443971-7746408.pdf?src-supplier=Element14; ComponentLink4Description: https://datasheet.ciiva.com/7660/38590-7660795.pdf?src-supplier=Element14; ComponentLink4URL: https://datasheet.ciiva.com/7660/38590-7660795.pdf?src-supplier=Element14; ComponentLink5Description: https://datasheet.ciiva.com/46412/443971-46412857.pdf?src-supplier=Element14; ComponentLink5URL: https://datasheet.ciiva.com/46412/443971-46412857.pdf?src-supplier=Element14; ComponentLink6Description: https://datasheet.ciiva.com/2454/pyu-r-int-thick-7-2454253.pdf?src-supplier=Digikey; ComponentLink6URL: https://datasheet.ciiva.com/2454/pyu-r-int-thick-7-2454253.pdf?src-supplier=Digikey; ComponentLink7Description: https://datasheet.ciiva.com/2454/pyu-r-int-thick-7-2454254.pdf?src-supplier=Digikey; ComponentLink7URL: https://datasheet.ciiva.com/2454/pyu-r-int-thick-7-2454254.pdf?src-supplier=Digikey; ComponentLink8Description: https://datasheet.ciiva.com/2454/pyu-r-int-thick-7-2454252.pdf?src-supplier=Digikey; ComponentLink8URL: https://datasheet.ciiva.com/2454/pyu-r-int-thick-7-2454252.pdf?src-supplier=Digikey; ComponentLink9Description: LCSC; ComponentLink9URL: https://www.lcsc.com/product-detail/Chip-Resistor-Surface-Mount_YAGEO-RC0603FR-078K2L_C114637.html; Depth: 0.8mm; Features: Moisture Resistant; Height: 0.022inch; Lead Free: 5697LeadFree; Lead Pitch: 0.3mm; Length: 0.063inch; Manufacturer Part Number: RC0603FR-078K2L; Max Operating Temperature: 155°C; Min Operating Temperature: -55°C; Number of Pins: 2; Number of Terminations: 2; Package Quantity: 1; Packaging: Tape and Reel; Power Rating: 100mW; Pricing 1: 5000=0.0015, 50000=0.0014, 150000=0.0014, 375000=0.0013, 1000000=0.0012 (USD); Reach SVHC Compliant: No; Resistance Tolerance: 1%; Resistor Type: Thick Film; RoHS: Yes; RoHS Compliant: Yes; Series: RC; Size Code: 0603; Stock 1: 690000; Supplier: LCSC; Supplier 1: Future Electronics; Supplier Part Number: C114637; Supplier Part Number 1: 9121856; Technology: THICK FILM; Termination: SMD/SMT; Tolerance: 1%; Voltage Rating (AC): 50V; Voltage Rating (DC): 50V; Width: 0.031inch; Library Name: josh-lib.SchLib
T1 = 7490220122: WE-LAN 10/100/1000 Base-T SMT Transformer 1 Port; Manufacturer: Wurth Electronics; Inductance: 350uH; Turns Ratio: 1:1; Category: Transformers; Component Kind: Standard; ComponentLink1Description: http://www.farnell.com/datasheets/2616617.pdf?src-supplier=Farnell; ComponentLink1URL: http://www.farnell.com/datasheets/2616617.pdf?src-supplier=Farnell; ComponentLink2Description: http://www.farnell.com/datasheets/2616617.pdf?src-supplier=Newark; ComponentLink2URL: http://www.farnell.com/datasheets/2616617.pdf?src-supplier=Newark; ComponentLink3Description: https://katalog.we-online.de/pbs/datasheet/7490220122.pdf?src-supplier=Digi-Key; ComponentLink3URL: https://katalog.we-online.de/pbs/datasheet/7490220122.pdf?src-supplier=Digi-Key; Manufacturer Part Number: 7490220122; Max Operating Temperature: 85°C; Min Operating Temperature: -40°C; Mount: Surface Mount; Packaging: Cut Tape; Pricing 1: 1=6.98, 10=6.731, 50=6.321, 100=5.951 (USD); RoHS: Yes; RoHS Compliant: Yes; Series: WE-LAN; Stock 1: 8221; Supplier 1: Digi-Key; Supplier Part Number 1: 732-10731-1-ND; Library Name: josh-lib.SchLib
TP1-TP11 = Test_Point: Library Name: josh-lib.SchLib
U1 = AG5800: IEEE802.3bt 4-Pair PD Module - works with AG6800; Manufacturer: Silvertel; Component Kind: Standard; Manufacturer Part Number: AG5800; Pricing 1: 1=25.36, 10=24.65, 24=23.92, 48=23.2, 120=22.47, 264=22.00008 (USD); RoHS: No; Stock 1: 862; Supplier 1: Digi-Key; Supplier Part Number 1: 5061-AG5800-ND; Library Name: josh-lib.SchLib
U2 U5 = LM5050MK-1/NOPB: IC OR CTRLR N+1 6SOT; Manufacturer: TI National Semiconductor; Max Output Current: 3.2uA; Max Supply Voltage: 75V; Min Supply Voltage: 5V; Nominal Supply Current: 130uA; Number of Drivers: 1; Quiescent Current: 400uA; Turn-Off Delay Time: 486ns; Case/Package: SOT-23-6; Category: Power Management ICs; Component Kind: Standard; ComponentLink1Description: https://datasheet.ciiva.com/22694/1642225-22694320.pdf?src-supplier=Element14; ComponentLink1URL: https://datasheet.ciiva.com/22694/1642225-22694320.pdf?src-supplier=Element14; ComponentLink2Description: https://datasheet.ciiva.com/12085/lm5050-1-122886-12085154.pdf?src-supplier=Mouser; ComponentLink2URL: https://datasheet.ciiva.com/12085/lm5050-1-122886-12085154.pdf?src-supplier=Mouser; ComponentLink3Description: https://datasheet.ciiva.com/15110/getdatasheetpartid-319925-15110173.pdf?src-supplier=Verical; ComponentLink3URL: https://datasheet.ciiva.com/15110/getdatasheetpartid-319925-15110173.pdf?src-supplier=Verical; ComponentLink4Description: https://datasheet.ciiva.com/1960/lm5050-1-1960856.pdf?src-supplier=Digi-Key; ComponentLink4URL: https://datasheet.ciiva.com/1960/lm5050-1-1960856.pdf?src-supplier=Digi-Key; ComponentLink5Description: https://datasheet.ciiva.com/1960/lm5050-1-1960857.pdf?src-supplier=Digi-Key; ComponentLink5URL: https://datasheet.ciiva.com/1960/lm5050-1-1960857.pdf?src-supplier=Digi-Key; ComponentLink6Description: https://datasheet.ciiva.com/1960/lm5050-1-1960858.pdf?src-supplier=Digi-Key; ComponentLink6URL: https://datasheet.ciiva.com/1960/lm5050-1-1960858.pdf?src-supplier=Digi-Key; Contact Plating: Tin; Lead Free: Lead Free; Manufacturer Part Number: LM5050MK-1/NOPB; Max Operating Temperature: 125°C; Min Operating Temperature: -40°C; Mount: Surface Mount; Number of Outputs: 1; Number of Pins: 6; Operating Supply Current: 130uA; Output Current: 3.2uA; Output Voltage: 75V; Package Quantity: 1000; Packaging: Tape and Reel; Pricing 1: 1=2.85000, 10=2.55900, 25=2.41400, 100=2.05690, 250=1.93132, 500=1.68990 (USD); Radiation Hardening: No; Reach SVHC Compliant: Yes; RoHS: Yes; RoHS Compliant: Yes; Series: -; Supplier 1: Digi-Key; Supplier Part Number 1: LM5050MK-1/NOPBDKR-ND; Supply Current: 130uA; Weight: 0.00127oz; Library Name: josh-lib.SchLib
U3 U4 U6-U8 = OR-3H7B-TP-G: 80V 3750V 50mA 0.4V@2.4mA,8mA 1 6V 1.2V DC SOP-4-1.27mm Optocouplers - Phototransistor Output ROHS; Manufacturer: Orient; Component Kind: Standard; ComponentLink1Description: LCSC; ComponentLink1URL: https://www.lcsc.com/product-detail/Optocouplers-Phototransistor-Output_Orient-OR-3H7B-TP-G_C282477.html; Manufacturer Part Number: OR-3H7B-TP-G; Supplier: LCSC; Supplier Part Number: C282477; Value: 80V 3750V 50mA; Library Name: josh-lib.SchLib
